# Altium schematic sheet 12V Power.SchDoc("12V Power")
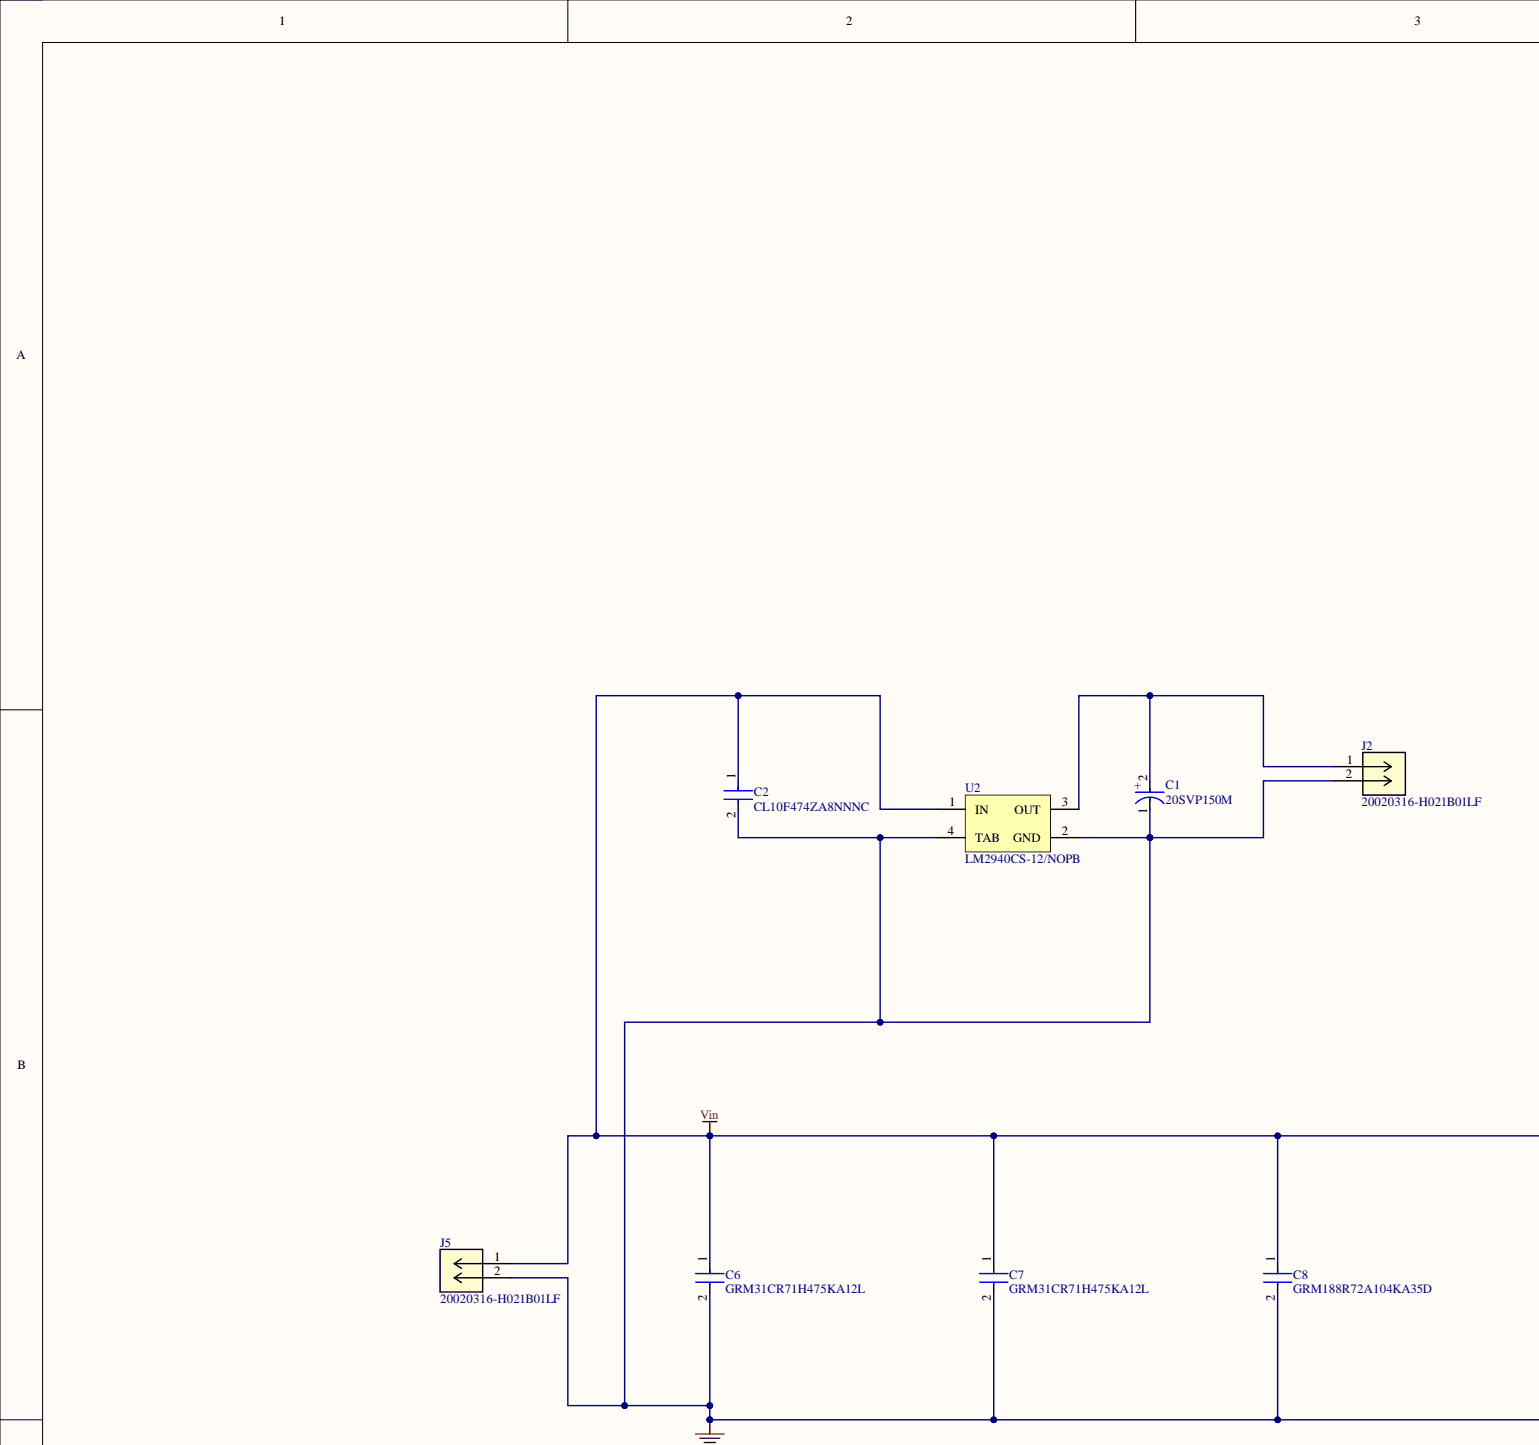
[diagram p0: 12V Power.SchDoc, top left]
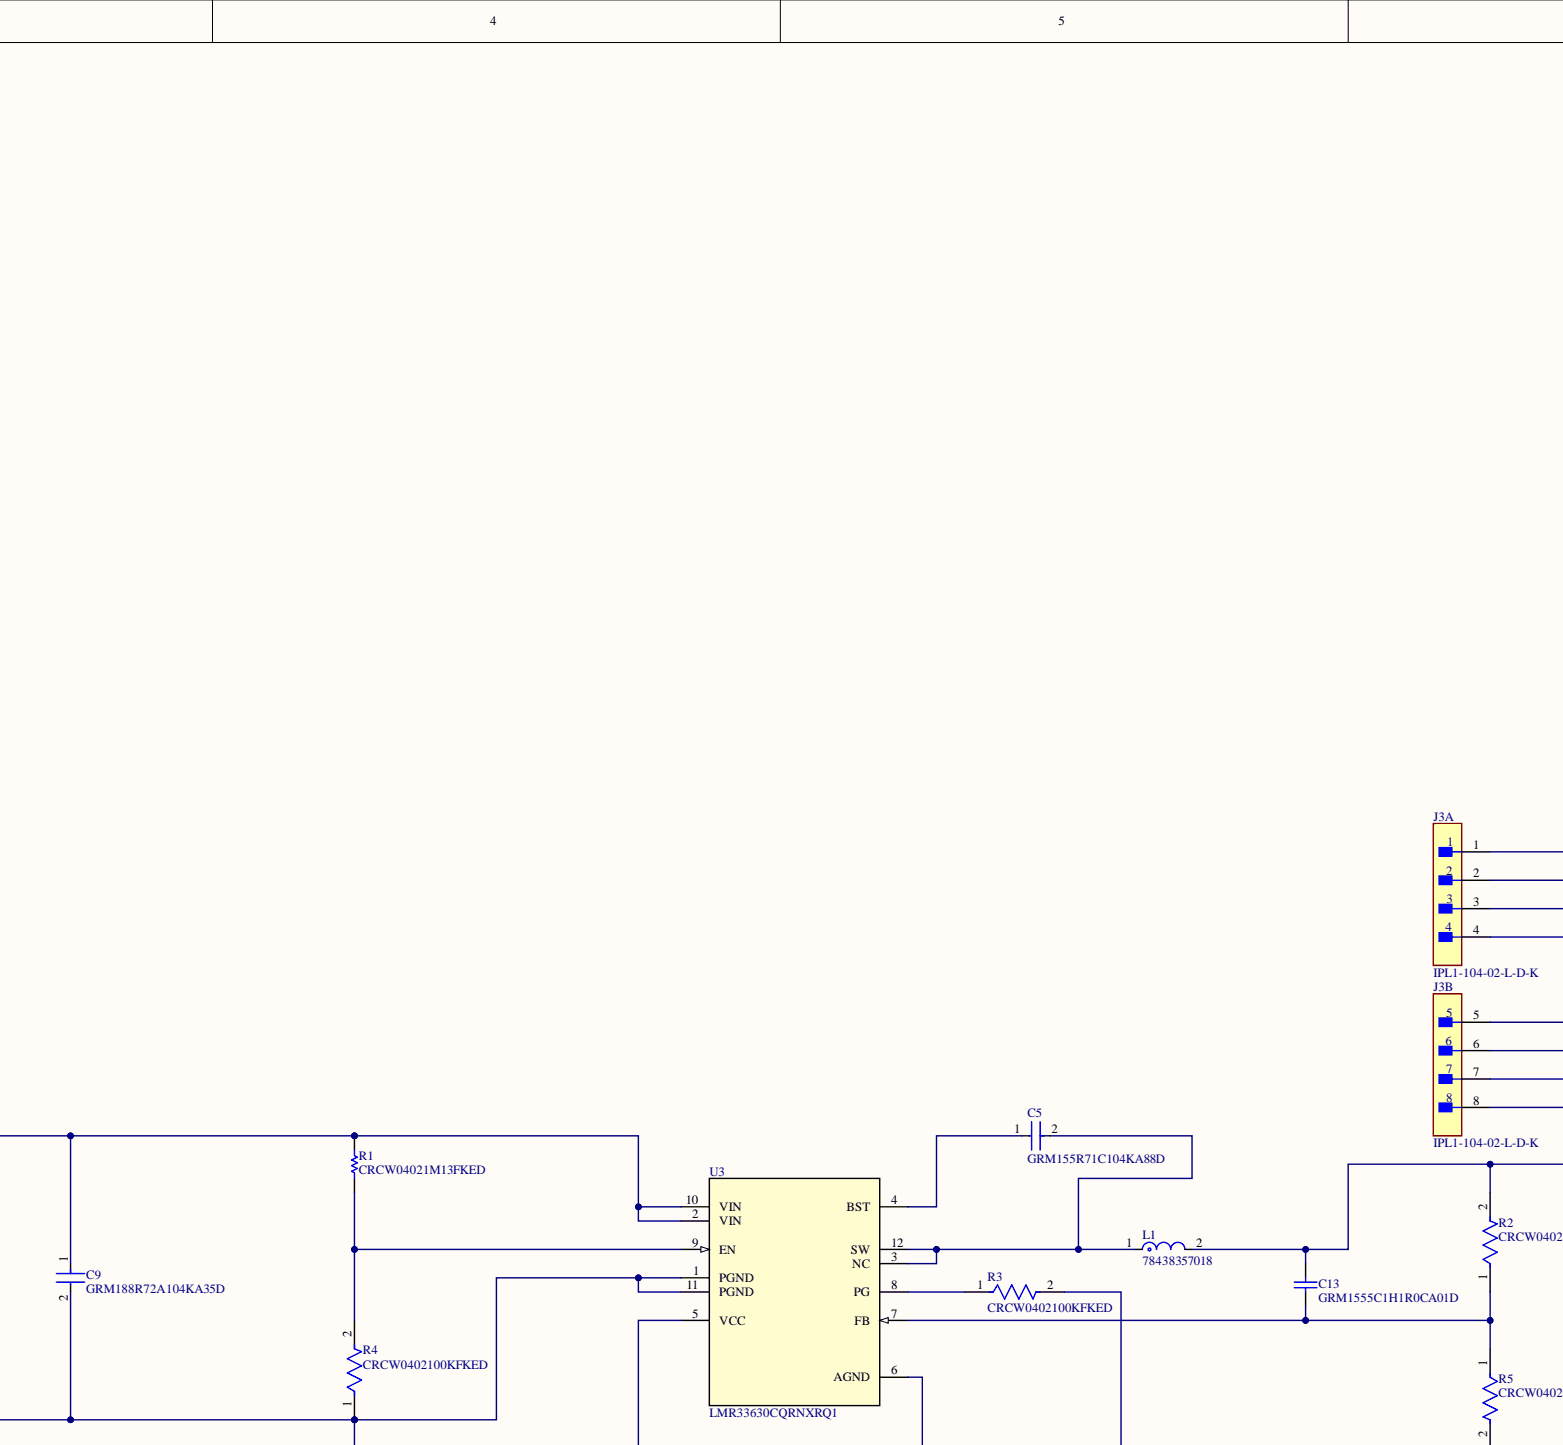
[diagram p1: 12V Power.SchDoc, top centre]
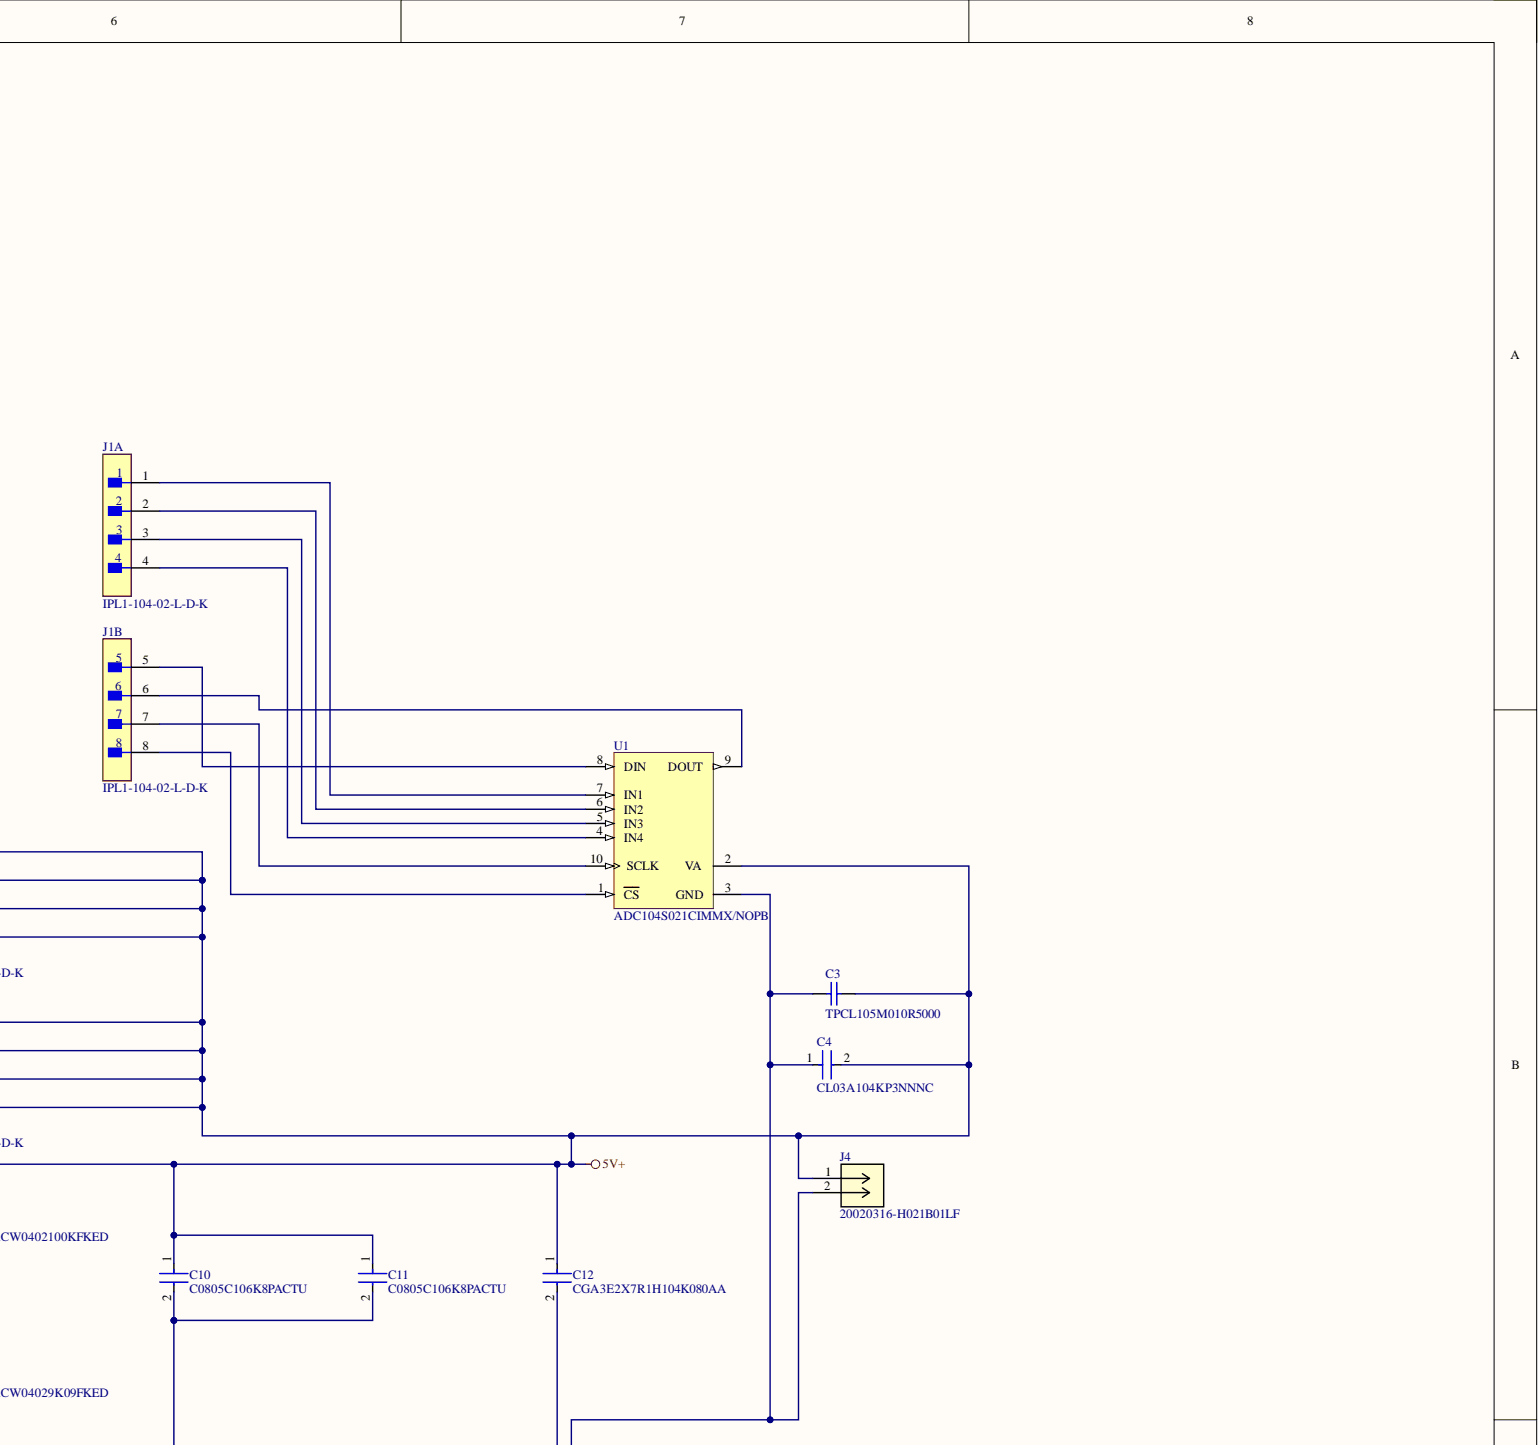
[diagram p2: 12V Power.SchDoc, top right]
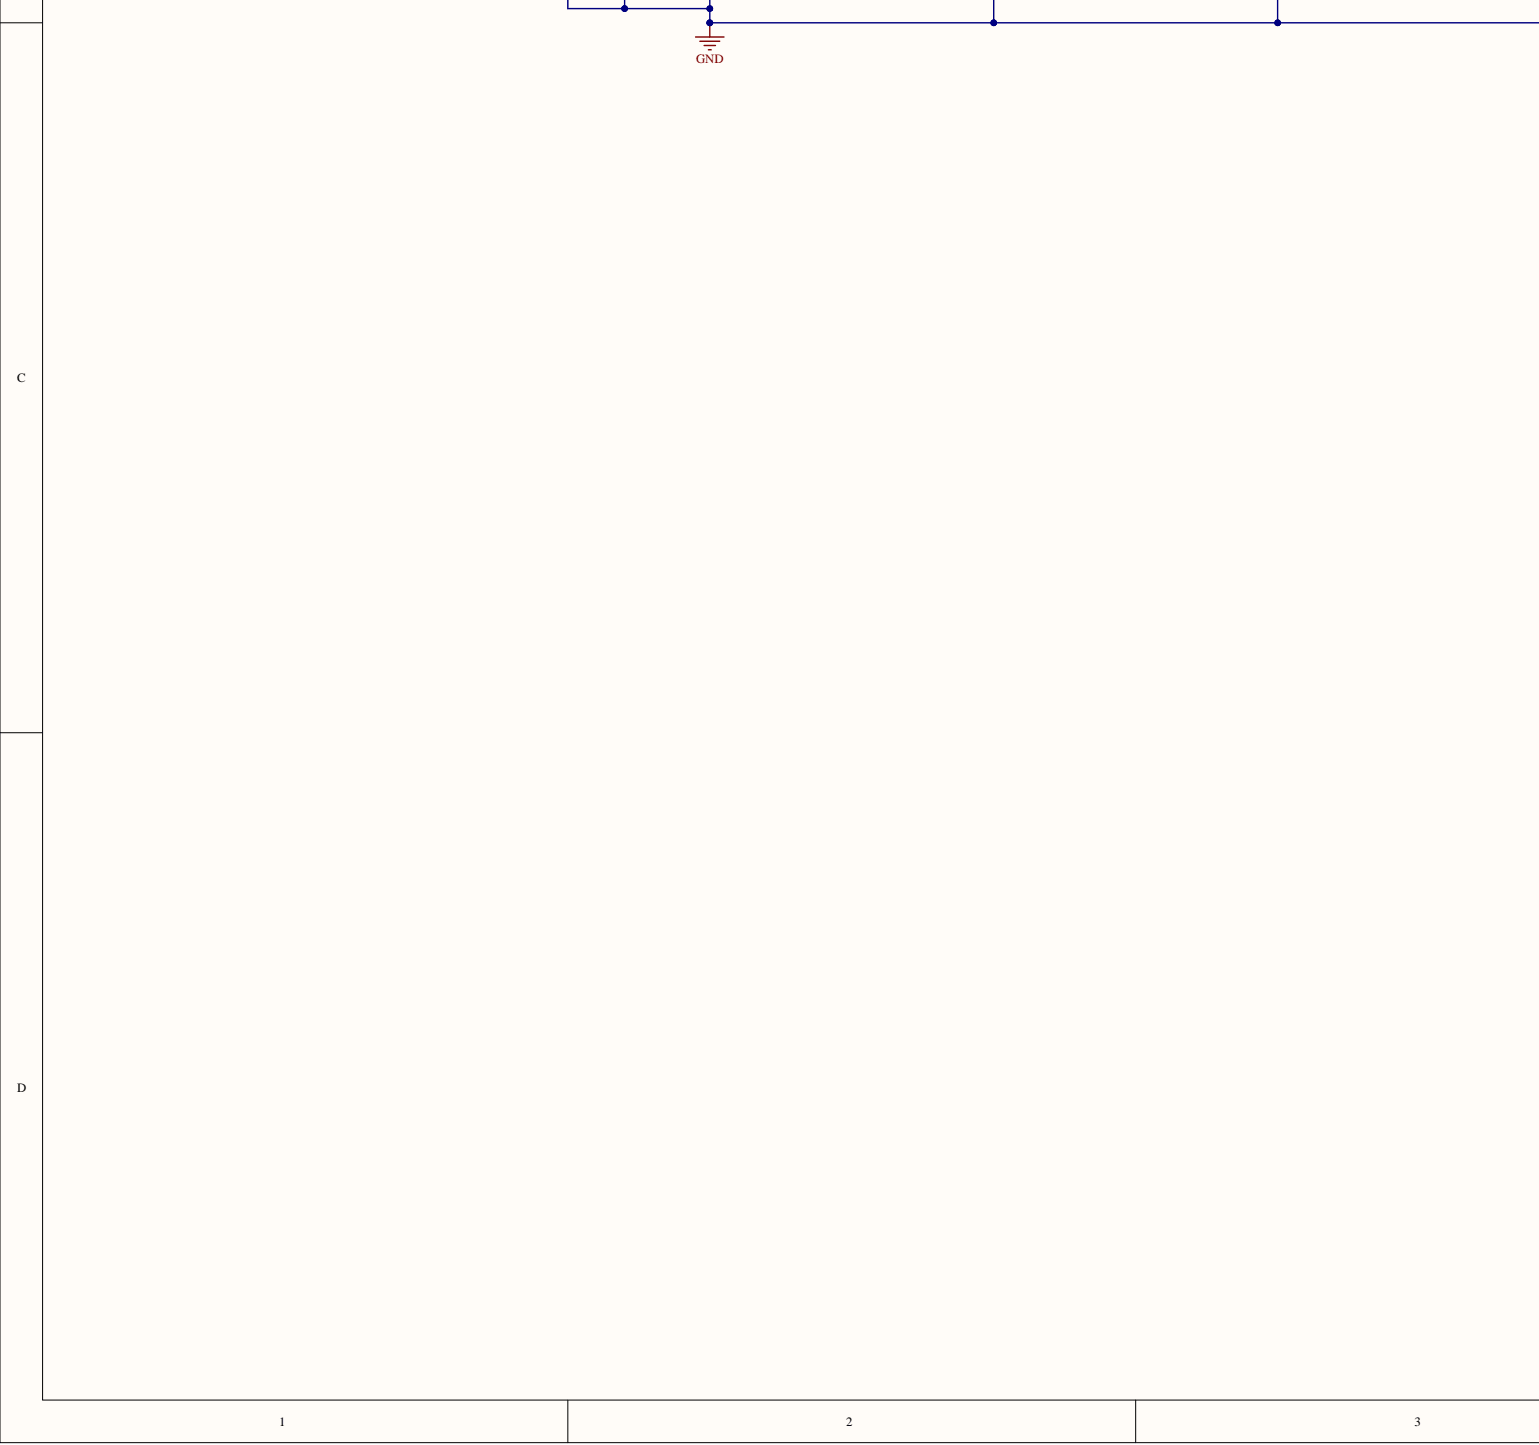
[diagram p3: 12V Power.SchDoc, bottom left]
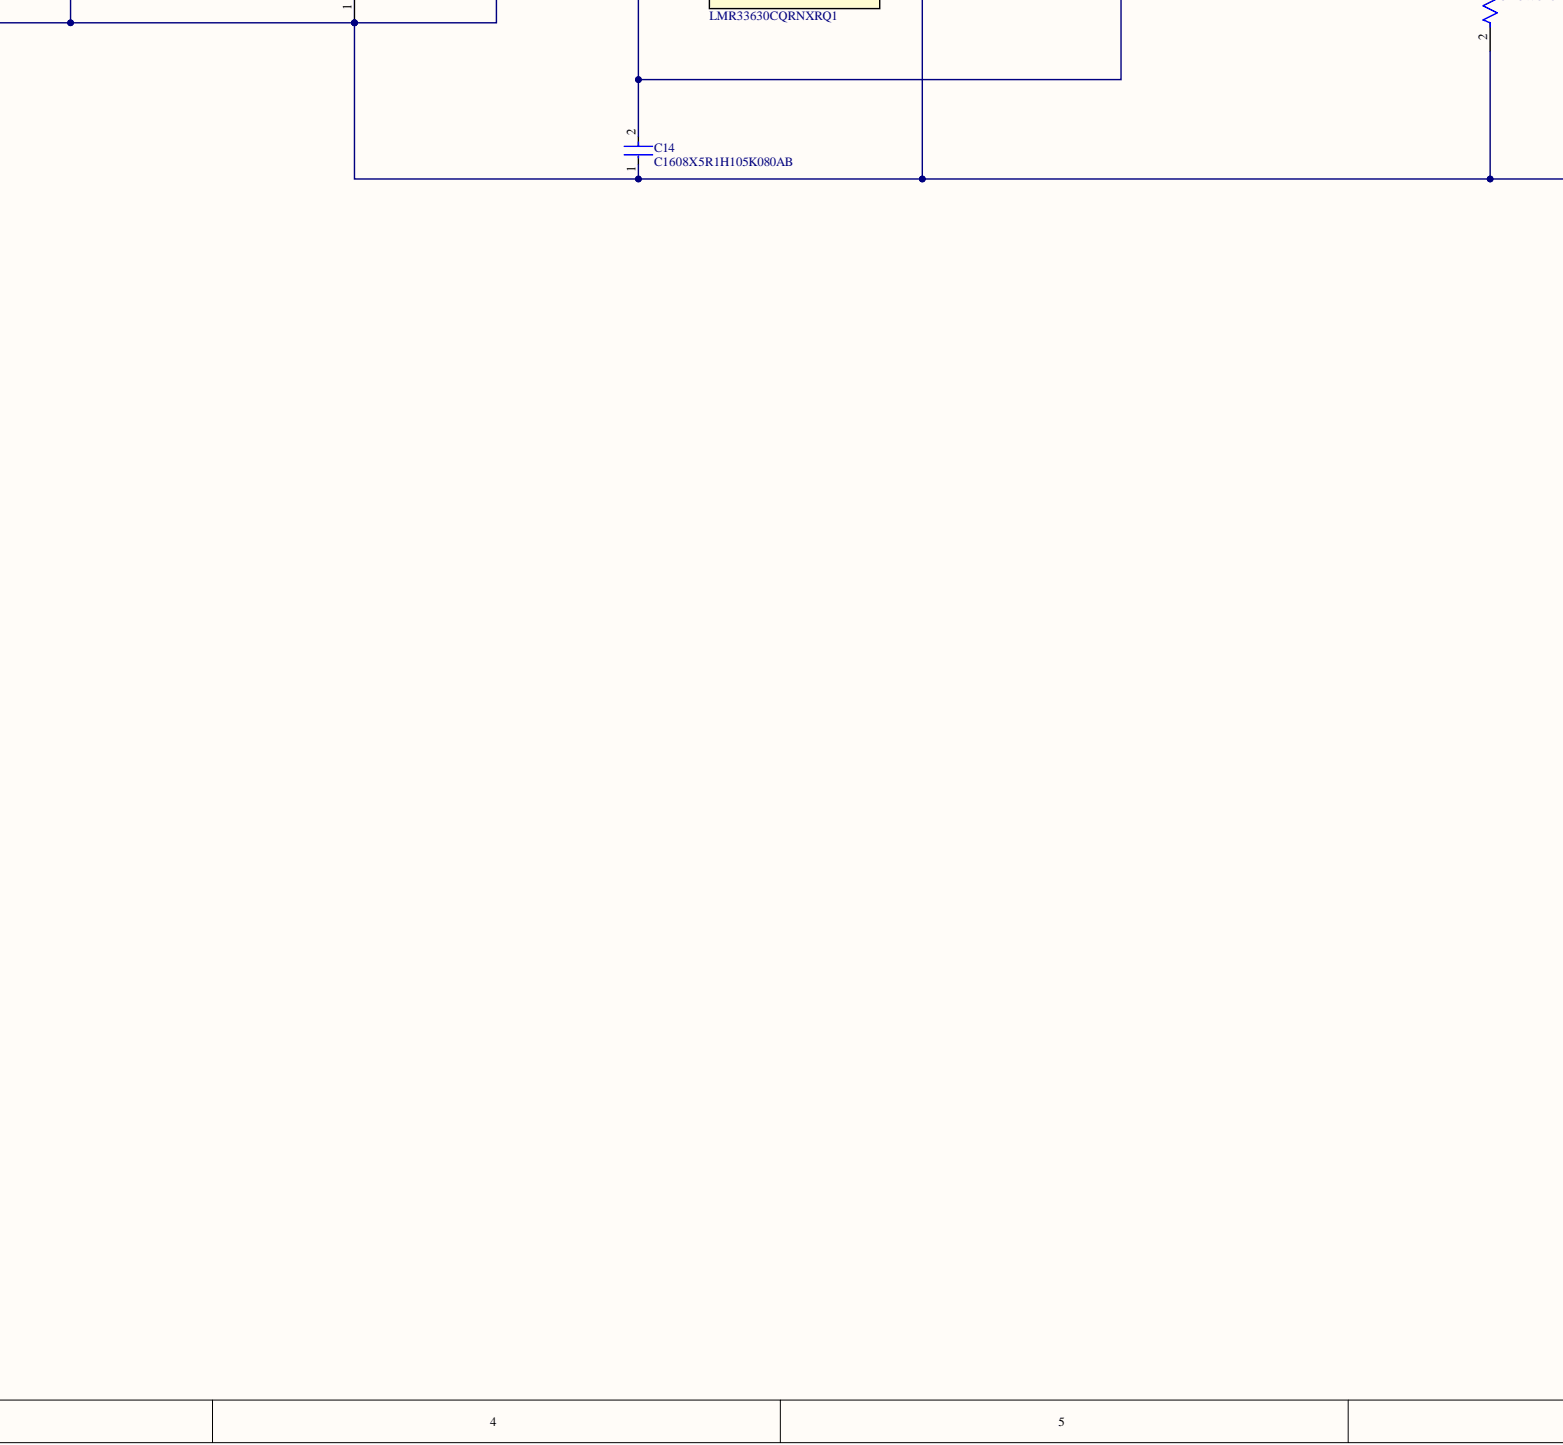
[diagram p4: 12V Power.SchDoc, bottom centre]
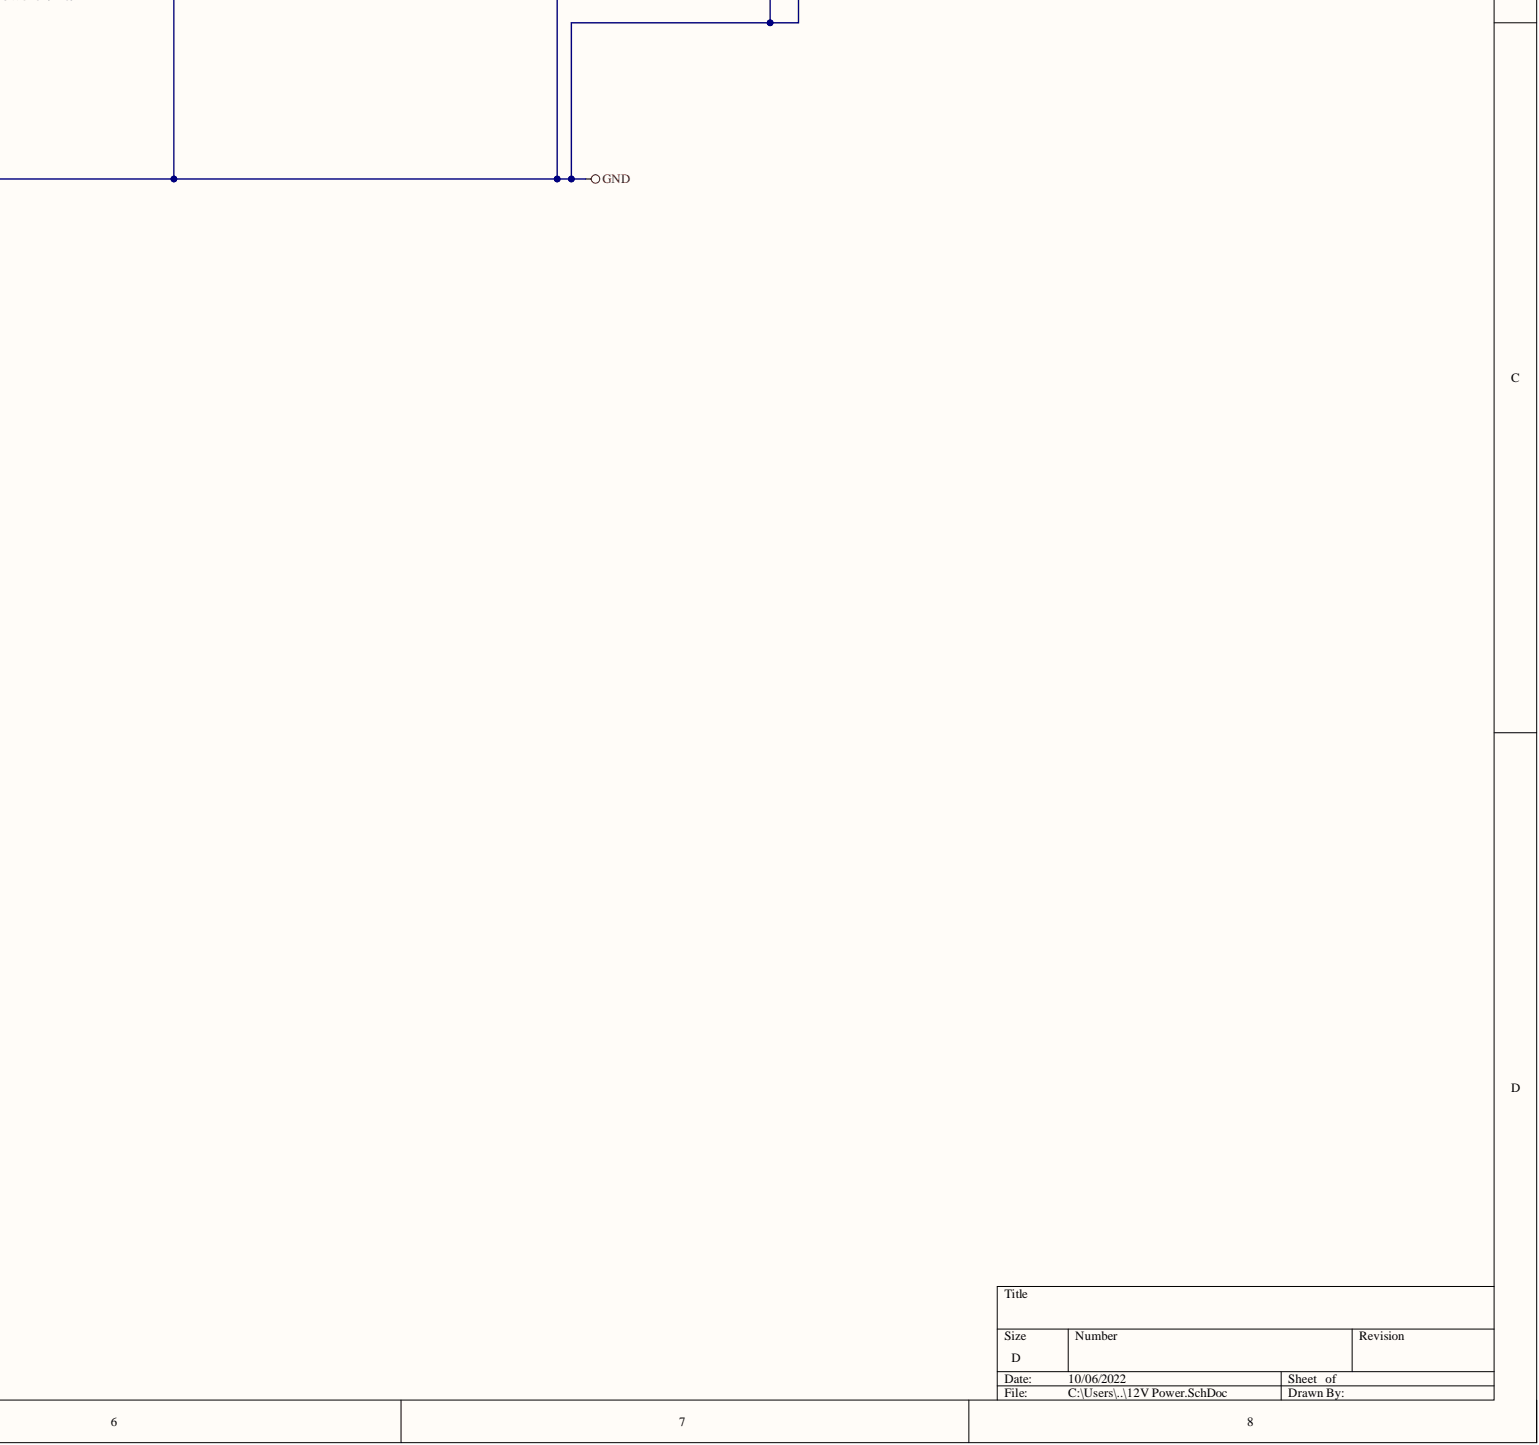
[diagram p5: 12V Power.SchDoc, bottom right]

## Parts
C1  20SVP150M  [FP-OS-CON-SMT-F12-MFG]
C2  CL10F474ZA8NNNC  [FP-CL10-IPC_C]
C3  TPCL105M010R5000  [CAPC1609X100X15LL20]
C4  CL03A104KP3NNNC  [FP-CL03-IPC_C]
C5  GRM155R71C104KA88D  [FP-GRM15-MFG]
C6  GRM31CR71H475KA12L  [FP-GRM31C-0_2-e0_3_0_8-IPC_C]
C7  GRM31CR71H475KA12L  [FP-GRM31C-0_2-e0_3_0_8-IPC_C]
C8  GRM188R72A104KA35D  [FP-GRM188-0_1-e0_2_0_5-IPC_B]
C9  GRM188R72A104KA35D  [FP-GRM188-0_1-e0_2_0_5-IPC_B]
C10  C0805C106K8PACTU  [FP-C0805C-DG-MFG]
C11  C0805C106K8PACTU  [FP-C0805C-DG-MFG]
C12  CGA3E2X7R1H104K080AA  [FP-CGA3E-0_3-0_1-IPC_A]
C13  GRM1555C1H1R0CA01D  [CAPC1005X55X25NL05T10]
C14  C1608X5R1H105K080AB  [FP-C1608-080-0_1-IPC_B]
J1  IPL1-104-02-L-D-K  [FP-IPL1-104-02-L-D-K-0]  (parts A,B)
J2  20020316-H021B01LF  [CONN_20020316-H021B01LF_AMP]
J3  IPL1-104-02-L-D-K  [FP-IPL1-104-02-L-D-K-0]  (parts A,B)
J4  20020316-H021B01LF  [CONN_20020316-H021B01LF_AMP]
J5  20020316-H021B01LF  [CONN_20020316-H021B01LF_AMP]
L1  78438357018  [FP-78438357018-MFG]
R1  CRCW04021M13FKED  [RESC1005X40X25ML05T05]
R2  CRCW0402100KFKED  [FP-CRCW0402-e3-IPC_C]
R3  CRCW0402100KFKED  [FP-CRCW0402-e3-IPC_C]
R4  CRCW0402100KFKED  [FP-CRCW0402-e3-IPC_C]
R5  CRCW04029K09FKED  [FP-CRCW0402-e3-IPC_A]
U1  ADC104S021CIMMX/NOPB  [MUB10A_N]
U2  LM2940CS-12/NOPB  [KTT0003B_N]
U3  LMR33630CQRNXRQ1  [RNX0012B]

## Nets
5V+: C3.1 C4.2 C10.1 C11.1 C12.1 C13.2 J3.1 J3.2 J3.3 J3.4 J3.5 J3.6 J3.7 J3.8 J4.1 L1.2 R2.2 U1.2(VA)
GND: C1.1 C2.2 C3.2 C4.1 C6.2 C7.2 C8.2 C9.2 C10.2 C11.2 C12.2 C14.1 J2.2 J4.2 J5.2 R4.1 R5.2 U1.3(GND) U2.2(GND) U2.4(TAB) U3.1(PGND) U3.6(AGND) U3.11(PGND)
Vin: C2.1 C6.1 C7.1 C8.1 C9.1 J5.1 R1.2 U2.1(IN) U3.2(VIN) U3.10(VIN)
NetC1_2: C1.2 J2.1 U2.3(OUT)
NetC5_1: C5.1 U3.4(BST)
NetC5_2: C5.2 L1.1 U3.3(NC) U3.12(SW)
NetC13_1: C13.1 R2.1 R5.1 U3.7(FB)
NetC14_2: C14.2 R3.2 U3.5(VCC)
NetJ1_1: J1.1 U1.7(IN1)
NetJ1_2: J1.2 U1.6(IN2)
NetJ1_3: J1.3 U1.5(IN3)
NetJ1_4: J1.4 U1.4(IN4)
NetJ1_5: J1.5 U1.8(DIN)
NetJ1_6: J1.6 U1.9(DOUT)
NetJ1_7: J1.7 U1.10(SCLK)
NetJ1_8: J1.8 U1.1(CS)
NetR1_1: R1.1 R4.2 U3.9(EN)
NetR3_1: R3.1 U3.8(PG)

## Library data of the parts
C1 = CMP-05428-000386-1: Conductive Polymer Aluminum Solid Capacitors (OS-CON) 150uF ±20% 20V RADIAL SMT 10x12.6mm; Dissipation Factor: 15%; ESR (Equivalent Series Resistance): 20mR; Leakage Current: 600uA; Life (Hours): 2000Hour; Polarity: Polar; Ripple Current: 4.32A; Capacitance: 150uF; Case/Package: Radial; Component Kind: Standard; Construction: Cylindrical; Diameter: 10mm; Height: 12.6mm; Height - Seated (Max): 0.5inch; Lead Free: Lead Free; Length: 12.6mm; Library Name: Altium Content Vault; Max Operating Temperature: 105°C; Min Operating Temperature: -55°C; Mount: Surface Mount; Number of Pins: 12; Package Quantity: 400; Packaging: Tape and Reel; Radiation Hardening: No; REACH SVHC: No SVHC; Ripple Current (AC): 4.32A; RoHS Compliant: Yes; Series: OS-CON™, SVP; Termination: SMD/SMT; Tolerance: 20%; Voltage: 20V; Voltage Rating: 20V; Voltage Rating (DC): 20V
C2 = CMP-13271-004088-1: Cap Ceramic 470nF 25V Y5V -20/+80% Pad SMD 0603 +85°C T/R; Tolerance: 80%; Capacitance: 470nF; Case Code (Imperial): 0603; Case Code (Metric): 1608; Case/Package: 0603; Component Kind: Standard; Depth: 0.8mm; Dielectric: Y5V; Height: 0.8mm; Lead Free: Lead Free; Length: 1.6mm; Library Name: Altium Content Vault; Max Operating Temperature: 85°C; Min Operating Temperature: -30°C; Mount: Surface Mount; Package Quantity: 1; Packaging: Tape and Reel; Radiation Hardening: No; Thickness: 0.035inch; Voltage Rating: 25V; Voltage Rating (DC): 25V; Width: 0.031inch
C3 = CMP-2006-00208-1: Manufacturer: AVX Interconnect / Elco; Component Kind: Standard; Library Name: Altium Content Vault; Part Number: TPCL105M010R5000
C4 = CMP-2010-00672-2: Cap Ceramic 100nF 10V X5R ±10% Pad SMD 0201 +85°C T/R; Capacitance: 100nF; Case Code (Imperial): 0201; Case Code (Metric): 0603; Case/Package: 0201; Component Kind: Standard; Contact Plating: Tin; Depth: 0.3mm; Dielectric: X5R; Height: 0.3mm; Lead Free: Lead Free; Length: 0.6mm; Library Name: Altium Content Vault; Max Operating Temperature: 85°C; Min Operating Temperature: -55°C; Mount: Surface Mount; Package Quantity: 1; Packaging: Tape and Reel; Radiation Hardening: No; Thickness: 0.013inch; Tolerance: 10%; Voltage Rating: 10V; Voltage Rating (DC): 10V; Width: 0.012inch
C5 = CMP-2100-03641-2: CAP Ceramic 0.10μF 10% X7R 16Vdc 0402 SMD; Manufacturer: Murata; Standoff Height: 0mm; Capacitance: 0.10μF; Category: Cap; Component Kind: Standard; ComponentLink2Description: Datasheet; ComponentLink2URL: https://search.murata.co.jp/Ceramy/image/img/A01X/G101/ENG/GRM155R71H471KA01-01A.pdf; Device Class L1: Passive Components; Device Class L2: Capacitors; Device Class L3: Ceramic Capacitors; Digikey Description: CAP CER 0.1UF 16V X7R 0402; Height: 0.55mm; Ipc Land Pattern Name: CAPC10050X219; Lead Free: yes; Library Name: Altium Content Vault; Manufacturer Part Number: GRM155R71C104KA88D; Material: Ceramic; Mouser Description: 0402 0.1 uF 16V ±10 % Tolerance X7R SMT Multilayer Ceramic Capacitor; Octopart: https://octopart.com/grm155r71c104ka88d-murata-196880; Package: GRM15; RoHS: TRUE; Temperature: -55°C to +125°C; Temperature Characteristic: X7R; Temperature Range High: +125°C; Temperature Range Low: -55°C; Tolerance: 10%; Value: 0.10μF; Voltage Rating: 16Vdc
C6 C7 = CMP-1037-04923-3: Chip Multilayer Ceramic Capacitors for General Purpose, 1206, 4.7uF, X7R, 15%, 10%, 50V; Capacitance: 4.7uF; Case Code (Imperial): 1206; Case Code (Metric): 3216; Case/Package: 1206; Component Kind: Standard; Depth: 1.6mm; Dielectric: X7R; Height: 1.6mm; Lead Free: Lead Free; Length: 3.2mm; Library Name: Altium Content Vault; Material: Ceramic; Max Operating Temperature: 125°C; Min Operating Temperature: -55°C; Mount: Surface Mount; Package Quantity: 2000; Packaging: Tape and Reel; Radiation Hardening: No; REACH SVHC: No SVHC; Termination: SMD/SMT; Thickness: 0.071inch; Tolerance: 10%; Voltage: 50V; Voltage Rating: 50V; Voltage Rating (DC): 50V; Width: 0.063inch
C8 C9 = CMP-1035-02595-8: Chip Multilayer Ceramic Capacitors for General Purpose, 0603, 0.10uF, X7R, 15%, 10%, 100V; Capacitance: 100nF; Case Code (Imperial): 0603; Case Code (Metric): 1608; Case/Package: 0603; Component Kind: Standard; Depth: 0.8mm; Dielectric: X7R; Height: 0.8mm; Lead Free: Lead Free; Length: 1.6mm; Library Name: Altium Content Vault; Material: Ceramic; Max Operating Temperature: 125°C; Min Operating Temperature: -55°C; Mount: Surface Mount; Package Quantity: 1; Packaging: Tape and Reel; Radiation Hardening: No; REACH SVHC: No SVHC; Termination: SMD/SMT; Thickness: 0.035inch; Tolerance: 10%; Voltage: 100V; Voltage Rating: 100V; Voltage Rating (DC): 100V; Weight: 0.000222oz; Width: 0.8mm
C10 C11 = CMP-2007-03243-2: CAP CER 10UF 10V X5R 0805; 3DS Part Number: 220-00001; Manufacturer: Kemet; Material: Ceramic; Temperature Coefficient: 15%; Tolerance: 10%; Automotive: No; Capacitance: 10uF; Category: Cap; Component Kind: Standard; ComponentLink2Description: Datasheet; ComponentLink2URL: https://content.kemet.com/datasheets/KEM_C1006_X5R_SMD.pdf; Device Class L1: Passive Components; Device Class L2: Capacitors; Device Class L3: Ceramic Capacitors; Digikey Description: CAP CER 10UF 10V X5R 0805; Height: 1.4mm; Ipc Land Pattern Name: CAPC200125X125; Lead Free: Yes; Library Name: Altium Content Vault; Manufacturer Part Number: C0805C106K8PACTU; Mouser Description: CAP CER 10UF 10V X5R 0805; Octopart: https://octopart.com/c0805c106k8pactu-kemet-98697; Package: C0805C-DG; RoHS: TRUE; Temperature: -55°C to +85°C; Temperature Characteristic: X5R; Temperature Range High: +85°C; Temperature Range Low: -55°C; Value: 10uF; Voltage: 10V; Voltage Rating: 10V
C12 = CMP-2000-07546-2: Cap Ceramic 100nF 50V X7R 10% Pad SMD 0603 +125°C Automotive T/R; Capacitance: 100nF; Case Code (Imperial): 0603; Case Code (Metric): 1608; Case/Package: 0603; Component Kind: Standard; Composition: Ceramic; Depth: 0.8mm; Dielectric: X7R; Height: 0.8mm; Lead Free: Lead Free; Length: 1.6mm; Library Name: Altium Content Vault; Max Operating Temperature: 125°C; Min Operating Temperature: -55°C; Mount: Surface Mount; Package Quantity: 1; Packaging: Tape and Reel; Radiation Hardening: No; Ratings: AEC-Q200; REACH SVHC: No SVHC; Termination: SMD/SMT; Thickness: 0.035inch; Tolerance: 10%; Voltage: 50V; Voltage Rating: 50V; Voltage Rating (DC): 50V; Weight: 7.1E-05oz; Width: 0.8mm
C13 = CMP-2008-04277-1: Manufacturer: Murata; Component Kind: Standard; Library Name: Altium Content Vault; Part Number: GRM1555C1H1R0CA01D
C14 = CMP-2000-06025-2: Multilayer Ceramic Capacitors 1μF ±10% 50V X5R SMD 0603; Capacitance: 1uF; Case Code (Imperial): 0603; Case Code (Metric): 1608; Case/Package: 0603; Component Kind: Standard; Construction: Flat; Depth: 0.8mm; Dielectric: X5R; Dielectric Material: Ceramic; Height: 0.8mm; Lead Free: Lead Free; Length: 1.6mm; Library Name: Altium Content Vault; Max Operating Temperature: 85°C; Min Operating Temperature: -55°C; Mount: Surface Mount; Number of Pins: 2; Package Quantity: 1; Packaging: Tape and Reel; Radiation Hardening: No; REACH SVHC: No SVHC; Resistor Type: Ceramic; RoHS Compliant: Yes; Series: C; Termination: SMD/SMT; Thickness: 0.035inch; Tolerance: 10%; Voltage: 50V; Voltage Rating: 50V; Voltage Rating (DC): 50V; Weight: 7.1E-05oz; Width: 0.031inch
J1 J3 = CMP-02766-000804-1: 4 Position 2 Row .100" (2.54 mm) pitch connector; Gender: Male; Max Current Rating: 7.4A; Max Voltage Rating (AC): 675V; Number of Contacts: 8; Number of Ports: 1; Pitch: 2.54mm; Component Kind: Standard; Contact Material: Bronze; Contact Plating: Gold; Depth: 9.58mm; Housing Material: Polymer; Length: 11.68mm; Library Name: Altium Content Vault; Max Operating Temperature: 125°C; Min Operating Temperature: -55°C; Mount: Surface Mount; Number of Terminals/Pins: 8; Orientation: Straight; Packaging: Rail/Tube; Radiation Hardening: No; Termination: Solder
J2 J4 J5 = 20020316-H021B01LF: No Description Available; Mfr_Name: Amphenol ICC; Component Kind: Standard; Library Name: 12V Power lib.SchLib; Manufacturer_Part_Number: 20020316-H021B01LF; RefDes: RefDes; Type: DEV; Copyright: Copyright (C) 2022 Ultra Librarian. All rights reserved.
L1 = CMP-207846-000027-1: FIXED IND 1.8UH 5.8A 21MOHM SMD; Core Material: Ferrite; DC Resistance (DCR): 21mR; Saturation Current: 8A; Self Resonant Frequency: 42MHz; Shielding: Shielded; Test Frequency: 100kHz; Tolerance: 20%; Case/Package: Nonstandard; Component Kind: Standard; Current Rating: 5.8A; Inductance: 1.8uH; Library Name: Altium Content Vault; Max Operating Temperature: 125°C; Min Operating Temperature: -40°C; Mount: Surface Mount; Packaging: Tape and Reel; Ratings: AEC-Q200; Resistor Type: Wirewound; RoHS Compliant: Yes
R1 = CMP-1011-00854-2: Manufacturer: Vishay Dale; Component Kind: Standard; Library Name: Altium Content Vault; Part Number: CRCW04021M13FKED
R2-R4 = CMP-2002-08123-3: RES Thick Film, 100kΩ, 1%, 0.063W, 100ppm/°C, 0402; Max Power Dissipation: 63mW; Resistance: 100kR; Voltage Rating: 50V; Case Code (Imperial): 0402; Case Code (Metric): 1005; Case/Package: 0402; Component Kind: Standard; Composition: Thick Film; Contact Plating: Tin; Depth: 0.5mm; Features: Automotive AEC-Q200; Height: 0.35mm; Lead Free: Lead Free; Length: 1mm; Library Name: Altium Content Vault; Max Operating Temperature: 155°C; Military Standard: Not; Min Operating Temperature: -55°C; Mount: Surface Mount; Number of Pins: 2; Number of Terminations: 2; Package Quantity: 10000; Packaging: Tape and Reel; Power Rating: 63mW; Radiation Hardening: No; Temperature Coefficient: 100ppm/°C; Termination: SMD/SMT; Tolerance: 1%; Voltage Rating (DC): 50V; Weight: 2.3E-05oz; Width: 0.02inch
R5 = CMP-2002-00285-2: RES Thick Film, 9.09kΩ, 1%, 0.063W, 100ppm/°C, 0402; Max Power Dissipation: 63mW; Resistance: 9.09kR; Voltage Rating: 50V; Case Code (Imperial): 0402; Case Code (Metric): 1005; Case/Package: 0402; Component Kind: Standard; Composition: Thick Film; Contact Plating: Tin; Depth: 0.5mm; Features: Automotive AEC-Q200; Height: 0.35mm; Lead Free: Lead Free; Length: 1mm; Library Name: Altium Content Vault; Max Operating Temperature: 155°C; Military Standard: Not; Min Operating Temperature: -55°C; Mount: Surface Mount; Number of Pins: 2; Number of Terminations: 2; Package Quantity: 10; Packaging: Tape and Reel; Power Rating: 63mW; Radiation Hardening: No; Temperature Coefficient: 100ppm/°C; Termination: SMD/SMT; Tolerance: 1%; Voltage Rating (DC): 50V; Weight: 2.3E-05oz; Width: 0.02inch
U1 = CMP-0054-00490-2: 4 Channel, 50 kSPS to 200 kSPS, 10-Bit A/D Converter, 10-pin Mini SOIC, Pb-Free; Manufacturer: National Semiconductor; CompType: ADC IC; PackageTopMarking: ZXTT*X20C; PowerWise: Y; Code_JEDEC: MO-187-BA; Component Kind: Standard; ComponentLink1Description: ADC104S021 Datasheet; ComponentLink1URL: http://www.national.com/ds/AD/ADC104S021.pdf; ComponentLink2Description: ADC104S021 Web Page; ComponentLink2URL: http://www.national.com/pf/AD/ADC104S021.html; ComponentLink3Description: MUB10A Package Page; ComponentLink3URL: http://www.national.com/packaging/folders/mub10a.html; DatasheetVersion: Feb-2010; Library Name: Altium Content Vault; PackageDescription: Mini-SO, Molded, .118 x .118 x .034in Body, 10 Lead, .0197in Pitch; PackageReference: MUB10A; PackageVersion: revB, Jul-2003; PinCount: 10; RevisionNote: Component URLs added; RoHS: Y
U2 = CMP-0062-00534-4: 1A Low Dropout Regulator, 3-pin TO-263, Pb-Free; Manufacturer: Texas Instruments; CompType: LDO IC; PackageTopMarking: NSUZXYTTE#*LM2940CS*-12 P+; PowerWise: N; Code_JEDEC: MO-169-AA; Component Kind: Standard; ComponentLink1Description: Datasheet URL; ComponentLink1URL: http://www.ti.com/general/docs/lit/getliterature.tsp?genericPartNumber=LM2940C&fileType=pdf; Library Name: Altium Content Vault; PackageDescription: TO-263, Molded, .400 x .390 x .180in, 3 Lead, .1in Pitch, Surface Mount; PackageReference: KTT0003B; PinCount: 3; RoHS: Y
U3 = LMR33630CQRNXRQ1_1: No Description Available; Mfr_Name: Texas Instruments; Component Kind: Standard; Library Name: 12V Power lib.SchLib; Manufacturer_Part_Number: LMR33630CQRNXRQ1; RefDes: RefDes; Type: DEV; Copyright: Copyright (C) 2022 Ultra Librarian. All rights reserved.
